# Altium schematic sheet Sheet1.SchDoc
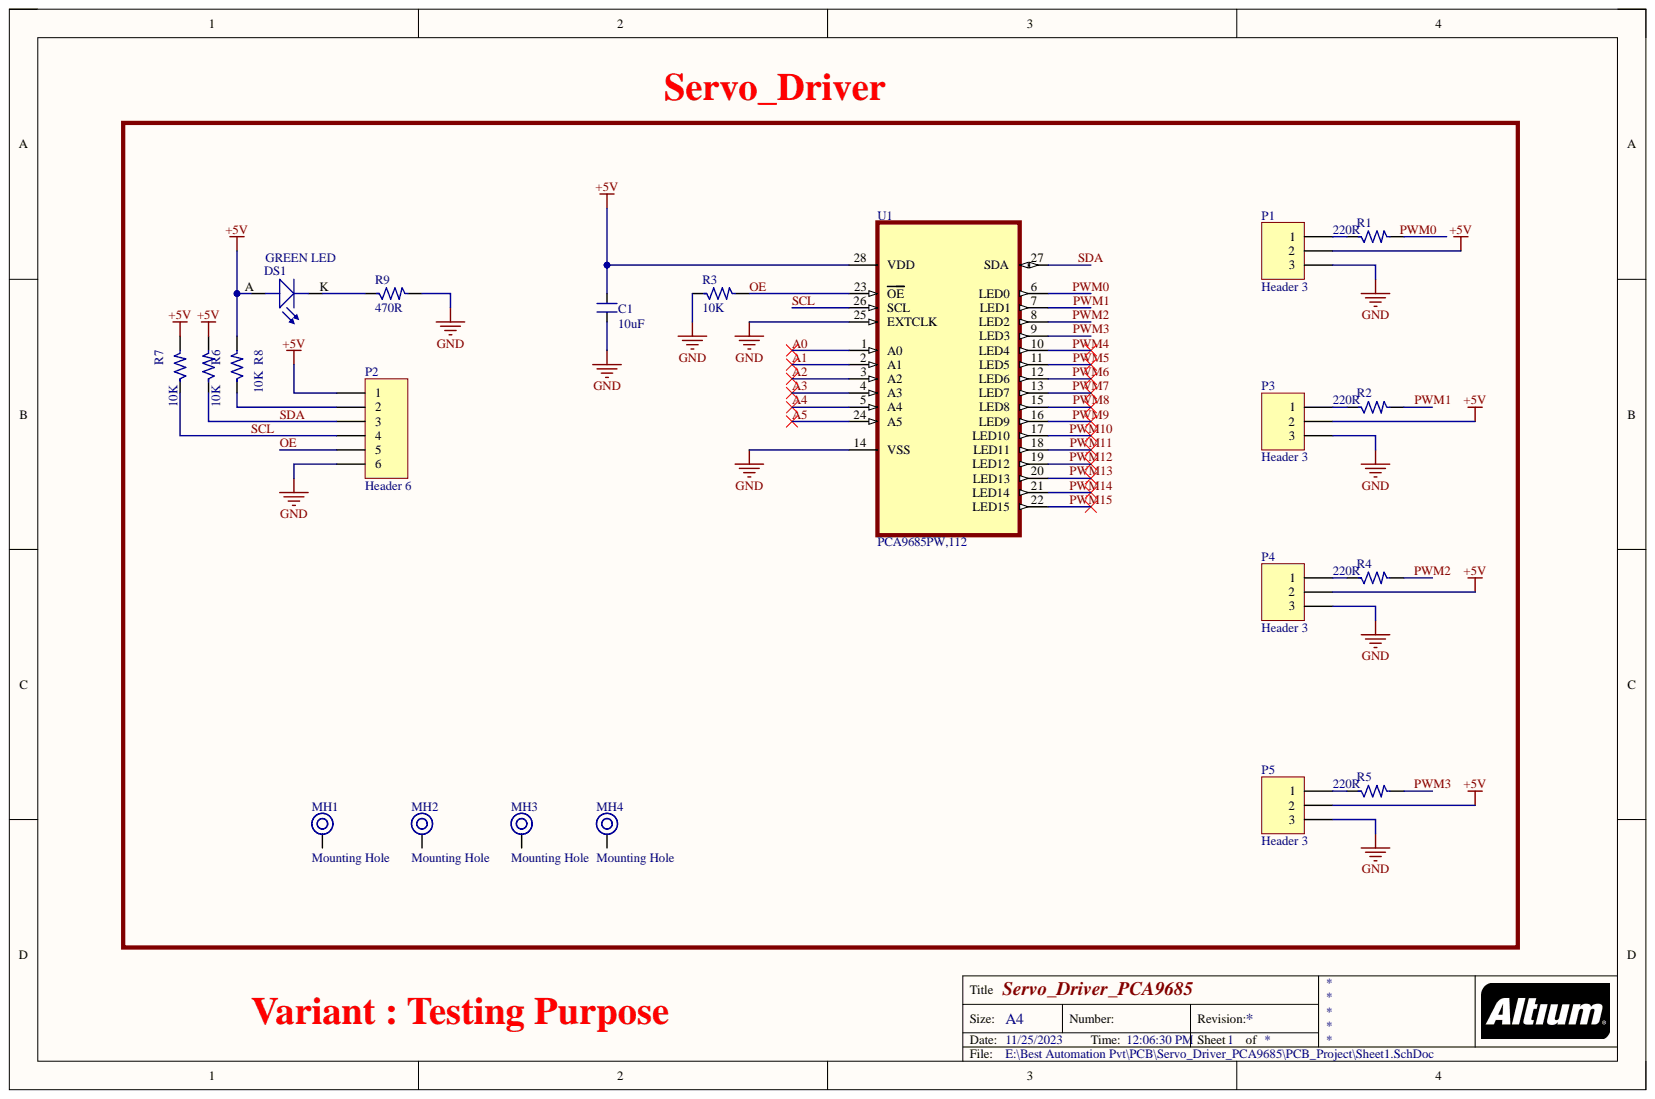
[diagram p0: Sheet1.SchDoc]

## Parts
C1  10uF  [CAP_1206]
DS1  GREEN LED  [LED_0805]
MH1  Mounting Hole  [MOUNTING]
MH2  Mounting Hole  [MOUNTING]
MH3  Mounting Hole  [MOUNTING]
MH4  Mounting Hole  [MOUNTING]
P1  Header 3  [HDR1X3]
P2  Header 6  [HDR1X6]
P3  Header 3  [HDR1X3]
P4  Header 3  [HDR1X3]
P5  Header 3  [HDR1X3]
R1  220R  [RES_1206]
R2  220R  [RES_1206]
R3  10K  [RES_1206]
R4  220R  [RES_1206]
R5  220R  [RES_1206]
R6  10K  [RES_1206]
R7  10K  [RES_1206]
R8  10K  [RES_1206]
R9  470R  [RES_1206]
U1  PCA9685PW,112  [SOP65P640X110-28N]

## Nets
+5V: C1.2 DS1.A P1.2 P2.1 P3.2 P4.2 P5.2 R6.2 R7.2 R8.2 U1.28(VDD)
GND: C1.1 P1.3 P2.6 P3.3 P4.3 P5.3 R3.1 R9.2 U1.14(VSS) U1.25(EXTCLK)
A0: U1.1(A0)
A1: U1.2(A1)
A2: U1.3(A2)
A3: U1.4(A3)
A4: U1.5(A4)
A5: U1.24(A5)
OE: P2.5 R3.2 U1.23(OE)
PWM0: R1.2 U1.6(LED0)
PWM1: R2.2 U1.7(LED1)
PWM2: R4.2 U1.8(LED2)
PWM3: R5.2 U1.9(LED3)
PWM4: U1.10(LED4)
PWM5: U1.11(LED5)
PWM6: U1.12(LED6)
PWM7: U1.13(LED7)
PWM8: U1.15(LED8)
PWM9: U1.16(LED9)
PWM10: U1.17(LED10)
PWM11: U1.18(LED11)
PWM12: U1.19(LED12)
PWM13: U1.20(LED13)
PWM14: U1.21(LED14)
PWM15: U1.22(LED15)
SCL: P2.4 R7.1 U1.26(SCL)
SDA: P2.3 R6.1 U1.27(SDA)
NetDS1_K: DS1.K R9.1
NetP1_1: P1.1 R1.1
NetP2_2: P2.2 R8.1
NetP3_1: P3.1 R2.1
NetP4_1: P4.1 R4.1
NetP5_1: P5.1 R5.1

Not connected: MH1 1; MH2 1; MH3 1; MH4 1

## Library data of the parts
C1 = CAP_10uF-50V-10%-1206_1: 10µF ±10% 50V Ceramic Capacitor X7R 1206 (3216 Metric); Alternate Part Number-1: GRT31CR61H106ME01L; Manufacturer: KEMET; Manufacturer Part Number: CL31B106KBHNNNE; Case/Package: 1206; Component Kind: Standard; Supplier Part Number-1: CC1206KKX5R9BB106; Supplier-1: SIRICOM; Library Name: Best_automation_lib.SchLib
DS1 = LED_ORANGE-2V-20mA-0805: Orange 605nm LED Indication - Discrete 2V 0805 (2012 Metric); Alternate Part Number-1: NA; Manufacturer: Vishay Lite-On; Manufacturer Part Number: LTST-C170KFKT; Case/Package: 0805; Component Kind: Standard; Library Name: ESTEEMPCBLIB.SchLib; Supplier Part Number-1: LTST-C170KFKT; Supplier-1: SP ROAD
MH1-MH4 = MH_3.5H_6D: Alternate Part Number-1: NA; Case/Package: TH; Component Kind: Standard; Description: Mounting Hole; Manufacturer: NA; Manufacturer Part Number: NA; Supplier Part Number-1: NA; Supplier-1: NA; Library Name: Best_automation_lib.SchLib
P1 P3-P5 = Header 3: Header, 3-Pin; Component Kind: Standard; LatestRevisionDate: 17-Jul-2002; LatestRevisionNote: Re-released for DXP Platform.; Library Name: Miscellaneous Connectors.IntLib; Signal Integrity: Connector; Publisher: Altium Limited
P2 = Header 6: Header, 6-Pin; Component Kind: Standard; LatestRevisionDate: 17-Jul-2002; LatestRevisionNote: Re-released for DXP Platform.; Library Name: Miscellaneous Connectors.IntLib; Signal Integrity: Connector; Publisher: Altium Limited
R1-R9 = RES_1M-0.25W-1%-1206: 1 MOhms ±1% 0.25W, 1/4W Chip Resistor 1206 (3216 Metric) Automotive AEC-Q200, High Voltage Thick Film; Alternate Part Number-1: NA; Manufacturer: Walsin; Manufacturer Part Number: WR12X1004FTL; Case/Package: 1206; Component Kind: Standard; Supplier Part Number-1: WR12X1004FTL; Supplier-1: SIRICOM; Library Name: Best_automation_lib.SchLib
U1 = PCA9685PW,112: LED Driver IC 16 Output Linear PWM Dimming 25mA 28-TSSOP; MF: NXP USA; Check_prices: https://www.snapeda.com/parts/PCA9685PW,112/NXP%20USA%20Inc./view-part/550390/?ref=eda; Component Kind: Standard; Digi-Key_Part_Number: 568-8366-5-ND; MP: PCA9685PW,112; Package: TSSOP-28 NXP Semiconductors; Purchase-URL: https://pricing.snapeda.com/search/part/PCA9685PW,112/?ref=eda; SnapEDA_Link: https://www.snapeda.com/parts/PCA9685PW,112/NXP%20USA%20Inc./view-part/550390/?ref=snap; Library Name: Best_automation_lib.SchLib
